# Altium schematic sheet Sheet1.SchDoc
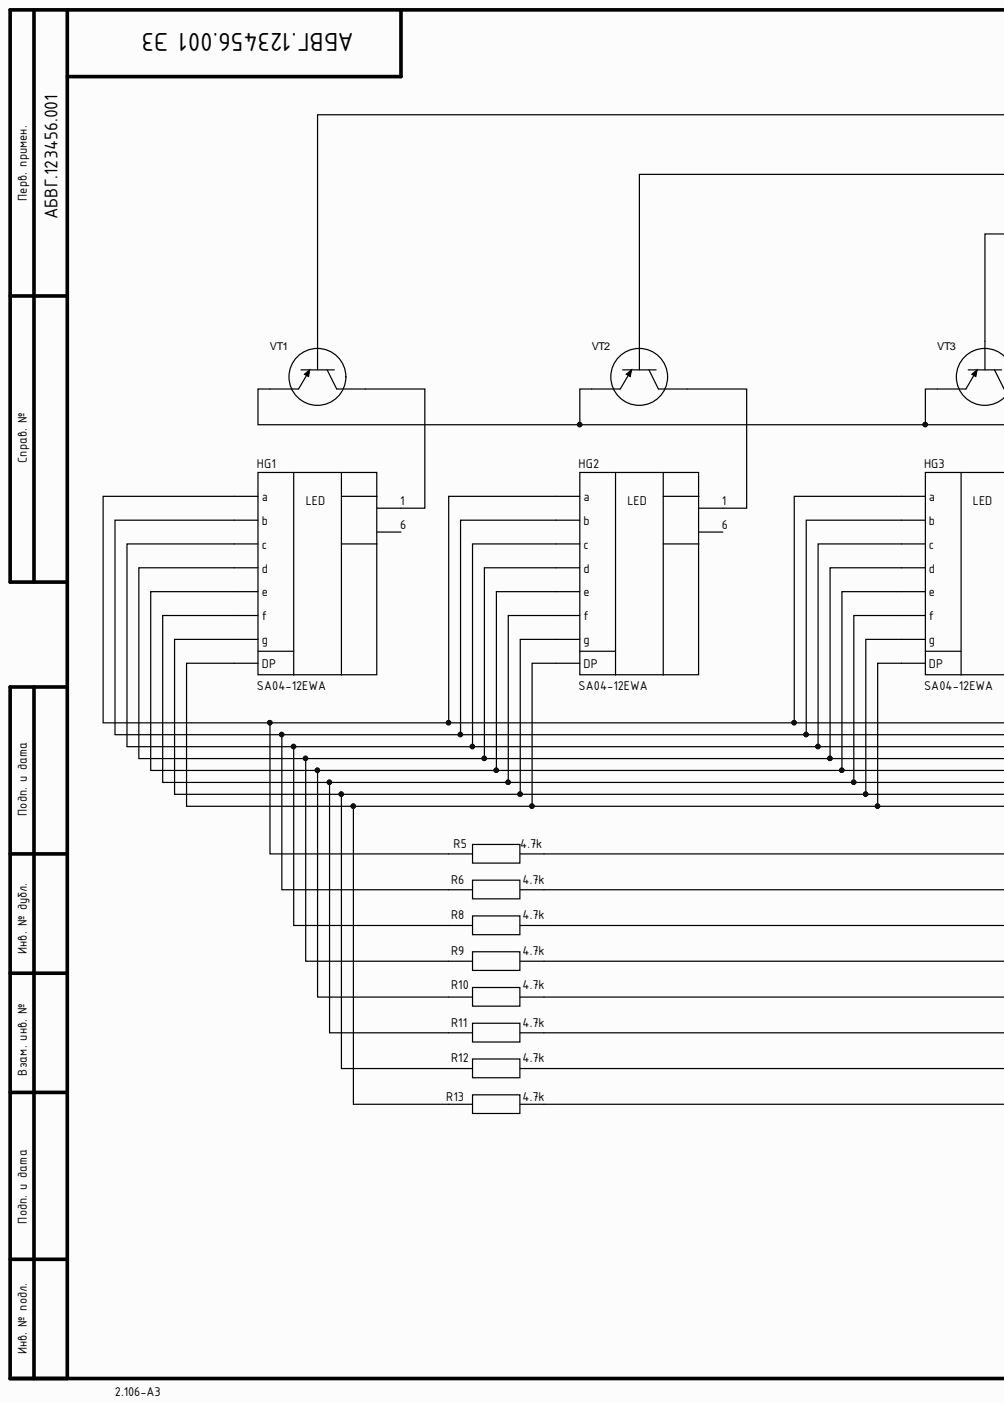
[diagram p0: Sheet1.SchDoc, left]
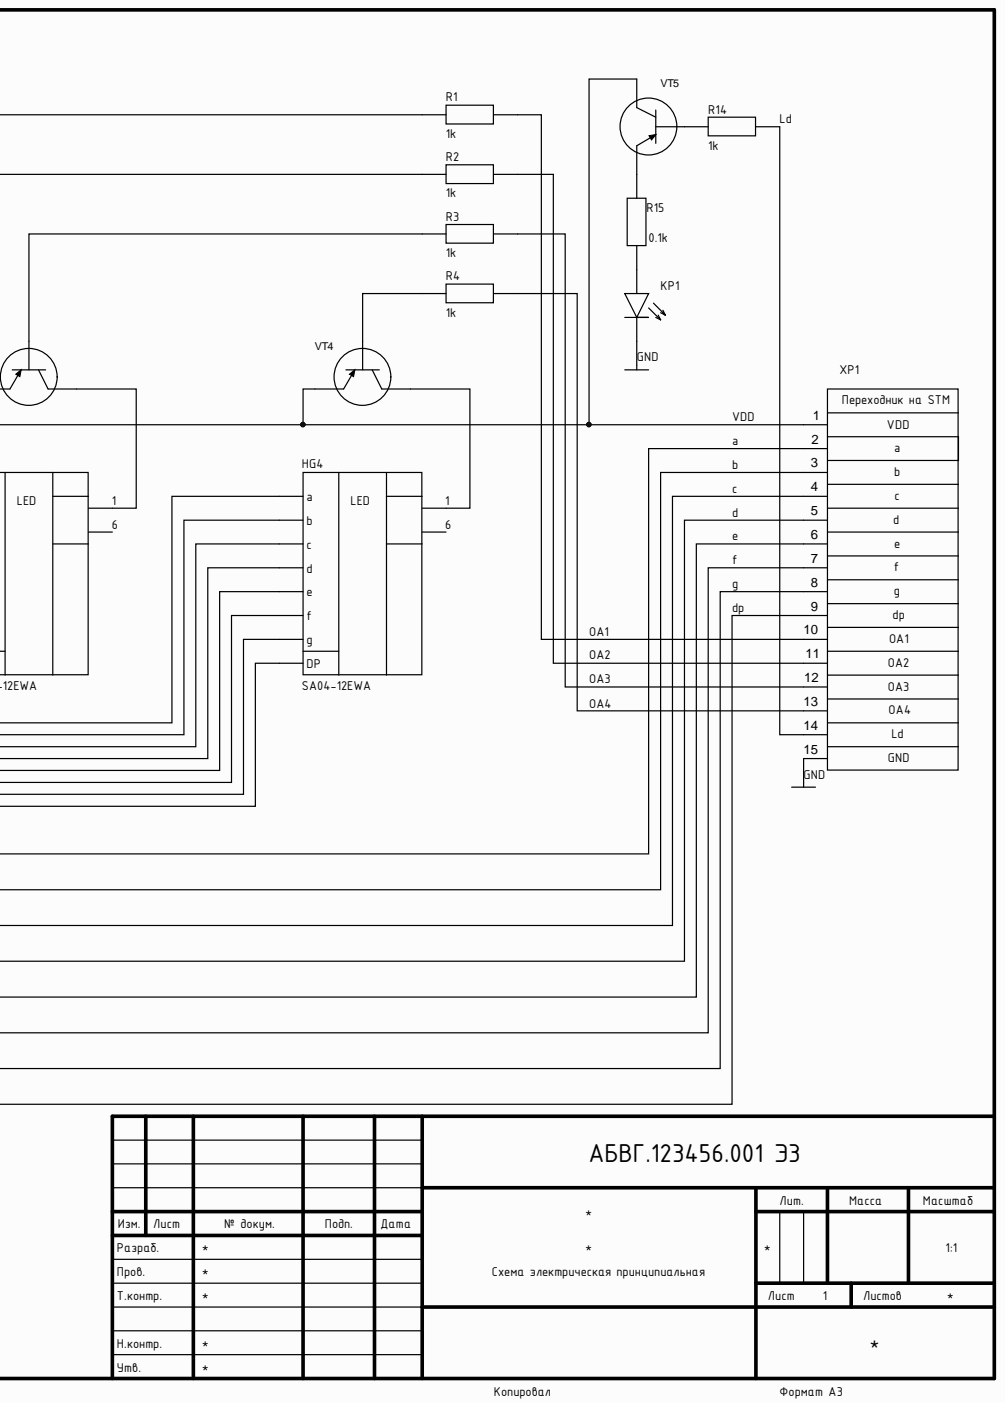
[diagram p1: Sheet1.SchDoc, right]

## Parts
HG1  SA04-12EWA  [Led-7]
HG2  SA04-12EWA  [Led-7]
HG3  SA04-12EWA  [Led-7]
HG4  SA04-12EWA  [Led-7]
KP1  light  [KP LD RED]  (value not drawn)
R1  1k  [Res 0805]
R2  1k  [Res 0805]
R3  1k  [Res 0805]
R4  1k  [Res 0805]
R5  4.7k  [Res 0805]
R6  4.7k  [Res 0805]
R8  4.7k  [Res 0805]
R9  4.7k  [Res 0805]
R10  4.7k  [Res 0805]
R11  4.7k  [Res 0805]
R12  4.7k  [Res 0805]
R13  4.7k  [Res 0805]
R14  1k  [Res 0805]
R15  0.1k  [Res 0805]
VT1  bipolar_pnp  [SOT-23]  (value not drawn)
VT2  bipolar_pnp  [SOT-23]  (value not drawn)
VT3  bipolar_pnp  [SOT-23]  (value not drawn)
VT4  bipolar_pnp  [SOT-23]  (value not drawn)
VT5  bipolar_pnp  [SOT-23]  (value not drawn)
XP1  PBD 1x16  [PBD - 1x15]  (value not drawn)

## Nets
a: R5.2 XP1.2
b: R6.2 XP1.3
c: R8.2 XP1.4
d: R9.2 XP1.5
dp: R13.2 XP1.9
e: R10.2 XP1.6
f: R11.2 XP1.7
g: R12.2 XP1.8
GND: KP1.2 XP1.15
Ld: R14.2 XP1.14
OA1: R1.2 XP1.10
OA2: R2.2 XP1.11
OA3: R3.2 XP1.12
OA4: R4.2 XP1.13
VDD: VT1.2 VT2.2 VT3.2 VT4.2 VT5.3 XP1.1
NetHG1_1: HG1.1 VT1.3
NetHG1_2: HG1.2(f) HG2.2(f) HG3.2(f) HG4.2(f) R11.1
NetHG1_3: HG1.3(g) HG2.3(g) HG3.3(g) HG4.3(g) R12.1
NetHG1_4: HG1.4(e) HG2.4(e) HG3.4(e) HG4.4(e) R10.1
NetHG1_5: HG1.5(d) HG2.5(d) HG3.5(d) HG4.5(d) R9.1
NetHG1_7: HG1.7(DP) HG2.7(DP) HG3.7(DP) HG4.7(DP) R13.1
NetHG1_8: HG1.8(c) HG2.8(c) HG3.8(c) HG4.8(c) R8.1
NetHG1_9: HG1.9(b) HG2.9(b) HG3.9(b) HG4.9(b) R6.1
NetHG1_10: HG1.10(a) HG2.10(a) HG3.10(a) HG4.10(a) R5.1
NetHG2_1: HG2.1 VT2.3
NetHG3_1: HG3.1 VT3.3
NetHG4_1: HG4.1 VT4.3
NetKP1_1: KP1.1 R15.2
NetR1_1: R1.1 VT1.1
NetR2_1: R2.1 VT2.1
NetR3_1: R3.1 VT3.1
NetR4_1: R4.1 VT4.1
NetR14_1: R14.1 VT5.1
NetR15_1: R15.1 VT5.2

Not connected: HG1 6; HG2 6; HG3 6; HG4 6

## Library data of the parts
HG1-HG4 = Led-7: Component Kind: Standard; Library Name: MyLibrary.SchLib
R1-R6 R8-R15 = Resistor: Component Kind: Standard; Library Name: MyLibrary.SchLib
  R1-R4 R14: Value: 1k
  R5 R6 R8-R13: Value: 4.7k
  R15: Value: 0.1k
KP1 = light: Component Kind: Standard; Library Name: MyLibrary.SchLib
VT1-VT5 = bipolar_pnp: Component Kind: Standard; Library Name: common.SchLib
XP1 = PBD 1x16: Component Kind: Standard; Library Name: MyLibrary.SchLib
